# Altium schematic sheet USB_Controller.SchDoc(U_USB_Controller)
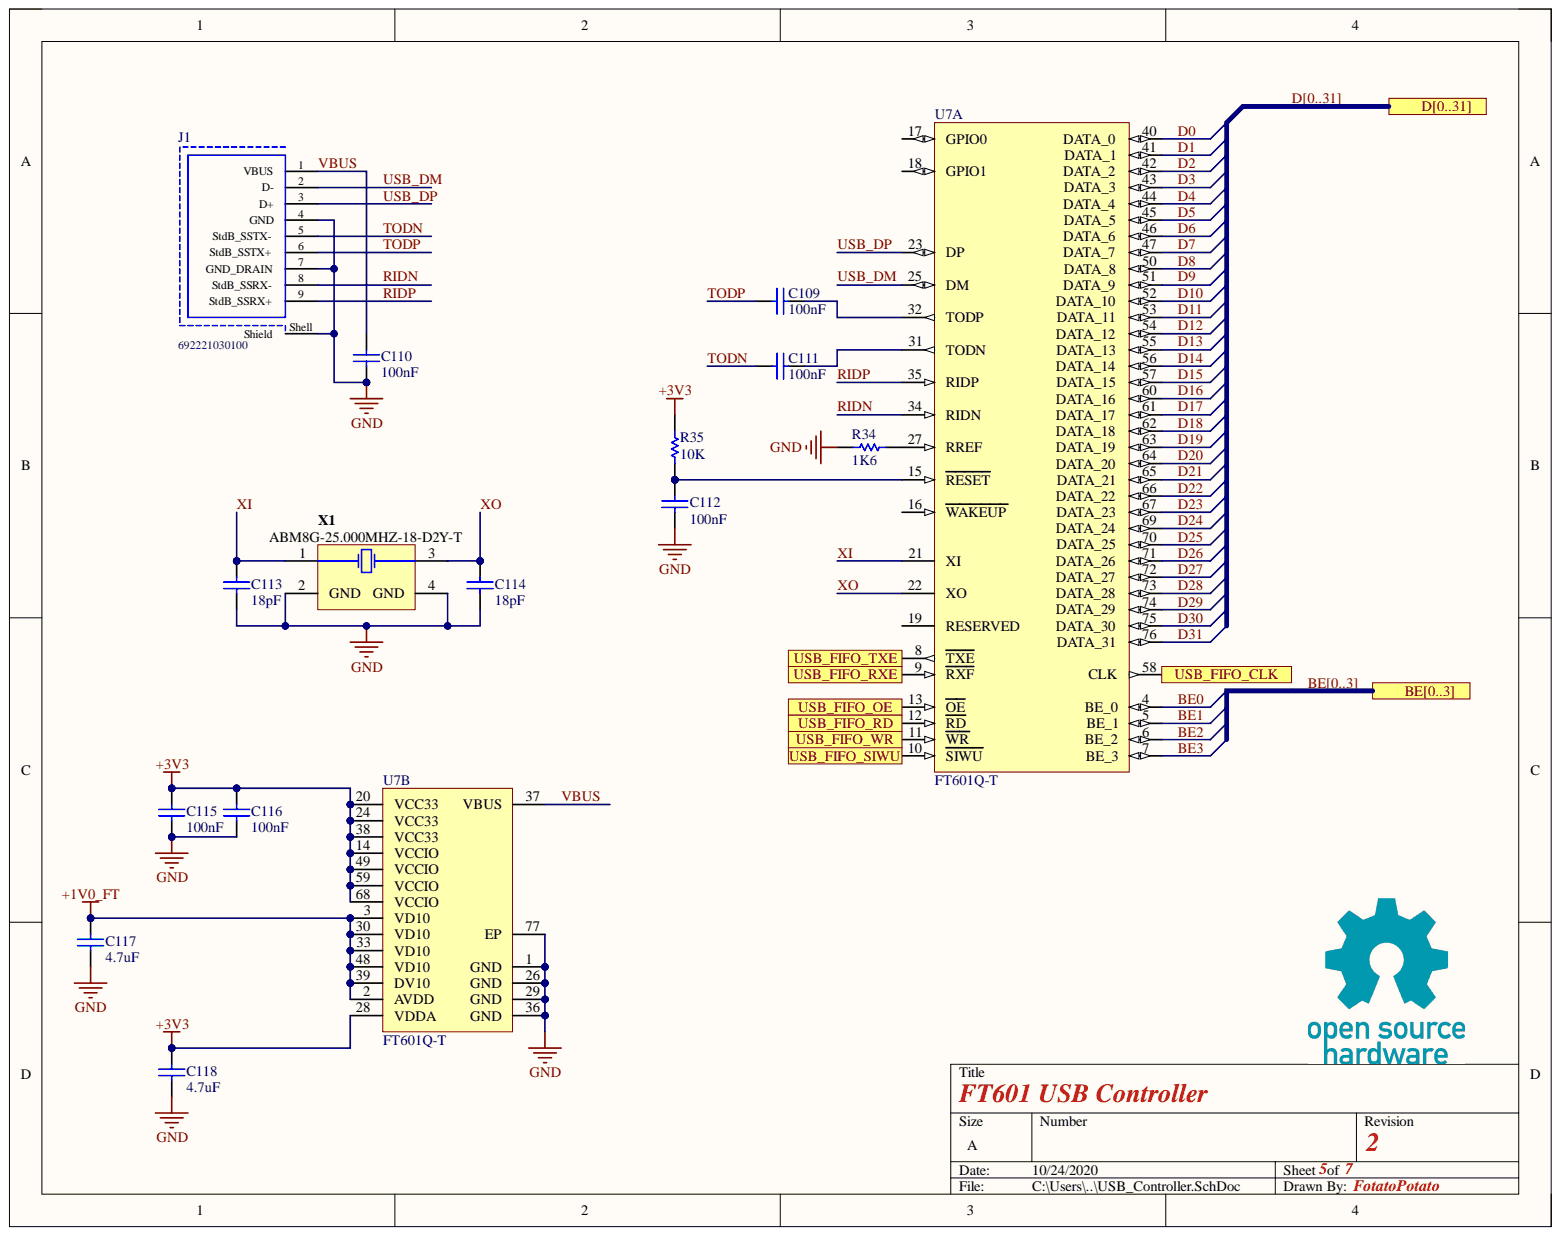
[diagram p0: USB_Controller.SchDoc]

## Parts
C109  100nF  [CAPC1005X56X25LL10T15]
C110  100nF  [CAPC1005X56X25LL10T15]
C111  100nF  [CAPC1005X56X25LL10T15]
C112  100nF  [CAPC1005X56X25LL10T15]
C113  18pF  [CAPC1005X56X25ML10T15]
C114  18pF  [CAPC1005X56X25ML10T15]
C115  100nF  [CAPC1005X56X25LL10T15]
C116  100nF  [CAPC1005X56X25LL10T15]
C117  4.7uF  [CAPC1005X55X25NL05T10]
C118  4.7uF  [CAPC1005X55X25NL05T10]
J1  692221030100  [692221030100]
R34  1K6  [RESC1005X40X25LL10T10]
R35  10K  [RESC1005X40X25NL10T10]
U7  FT601Q-T  [FTDI-QFN-76_M]  (parts A,B)
X1  ABM8G-25.000MHZ-18-D2Y-T  Load Capacitance: 18pF  [ABRA-ABM8G_V]

## Ports
BE0, BE1, BE2, BE3, BE[0..3], D0, D1, D2, D3, D4, D5, D6, D7, D8, D9, D10, D11, D12, D13, D14, D15, D16, D17, D18, D19, D20, D21, D22, D23, D24, D25, D26, D27, D28, D29, D30, D31, D[0..31], USB_FIFO_CLK, USB_FIFO_OE, USB_FIFO_RD, USB_FIFO_RXE, USB_FIFO_SIWU, USB_FIFO_TXE, USB_FIFO_WR

## Nets
+1V0_FT: C117.2 U7.2(AVDD) U7.3(VD10) U7.30(VD10) U7.33(VD10) U7.39(DV10) U7.48(VD10)
+3V3: C115.2 C116.2 C118.2 R35.2 U7.14(VCCIO) U7.20(VCC33) U7.24(VCC33) U7.28(VDDA) U7.38(VCC33) U7.49(VCCIO) U7.59(VCCIO) U7.68(VCCIO)
GND: C110.1 C112.2 C113.1 C114.1 C115.1 C116.1 C117.1 C118.1 J1.4(GND) J1.7(GND_DRAIN) J1.Shell(Shield) R34.1 U7.1(GND) U7.26(GND) U7.29(GND) U7.36(GND) U7.77(EP) X1.2(GND) X1.4(GND)
BE0: U7.4(BE_0) ; port BE[0..3]  [also labelled BE]
BE1: U7.5(BE_1) ; port BE[0..3]  [also labelled BE]
BE2: U7.6(BE_2) ; port BE[0..3]  [also labelled BE]
BE3: U7.7(BE_3) ; port BE[0..3]  [also labelled BE]
D0: U7.40(DATA_0) ; port D[0..31]  [also labelled D]
D1: U7.41(DATA_1) ; port D[0..31]  [also labelled D]
D2: U7.42(DATA_2) ; port D[0..31]  [also labelled D]
D3: U7.43(DATA_3) ; port D[0..31]  [also labelled D]
D4: U7.44(DATA_4) ; port D[0..31]  [also labelled D]
D5: U7.45(DATA_5) ; port D[0..31]  [also labelled D]
D6: U7.46(DATA_6) ; port D[0..31]  [also labelled D]
D7: U7.47(DATA_7) ; port D[0..31]  [also labelled D]
D8: U7.50(DATA_8) ; port D[0..31]  [also labelled D]
D9: U7.51(DATA_9) ; port D[0..31]  [also labelled D]
D10: U7.52(DATA_10) ; port D[0..31]  [also labelled D]
D11: U7.53(DATA_11) ; port D[0..31]  [also labelled D]
D12: U7.54(DATA_12) ; port D[0..31]  [also labelled D]
D13: U7.55(DATA_13) ; port D[0..31]  [also labelled D]
D14: U7.56(DATA_14) ; port D[0..31]  [also labelled D]
D15: U7.57(DATA_15) ; port D[0..31]  [also labelled D]
D16: U7.60(DATA_16) ; port D[0..31]  [also labelled D]
D17: U7.61(DATA_17) ; port D[0..31]  [also labelled D]
D18: U7.62(DATA_18) ; port D[0..31]  [also labelled D]
D19: U7.63(DATA_19) ; port D[0..31]  [also labelled D]
D20: U7.64(DATA_20) ; port D[0..31]  [also labelled D]
D21: U7.65(DATA_21) ; port D[0..31]  [also labelled D]
D22: U7.66(DATA_22) ; port D[0..31]  [also labelled D]
D23: U7.67(DATA_23) ; port D[0..31]  [also labelled D]
D24: U7.69(DATA_24) ; port D[0..31]  [also labelled D]
D25: U7.70(DATA_25) ; port D[0..31]  [also labelled D]
D26: U7.71(DATA_26) ; port D[0..31]  [also labelled D]
D27: U7.72(DATA_27) ; port D[0..31]  [also labelled D]
D28: U7.73(DATA_28) ; port D[0..31]  [also labelled D]
D29: U7.74(DATA_29) ; port D[0..31]  [also labelled D]
D30: U7.75(DATA_30) ; port D[0..31]  [also labelled D]
D31: U7.76(DATA_31) ; port D[0..31]  [also labelled D]
RIDN: J1.8(StdB_SSRX-) U7.34(RIDN)
RIDP: J1.9(StdB_SSRX+) U7.35(RIDP)
TODN: C111.2 J1.5(StdB_SSTX-)
TODP: C109.2 J1.6(StdB_SSTX+)
USB_DM: J1.2(D-) U7.25(DM)
USB_DP: J1.3(D+) U7.23(DP)
USB_FIFO_CLK: U7.58(CLK) ; port USB_FIFO_CLK
USB_FIFO_OE: U7.13(OE) ; port USB_FIFO_OE
USB_FIFO_RD: U7.12(RD) ; port USB_FIFO_RD
USB_FIFO_RXE: U7.9(RXF) ; port USB_FIFO_RXE
USB_FIFO_SIWU: U7.10(SIWU) ; port USB_FIFO_SIWU
USB_FIFO_TXE: U7.8(TXE) ; port USB_FIFO_TXE
USB_FIFO_WR: U7.11(WR) ; port USB_FIFO_WR
VBUS: C110.2 J1.1(VBUS) U7.37(VBUS)
XI: C113.2 U7.21(XI) X1.1
XO: C114.2 U7.22(XO) X1.3
NetC109_1: C109.1 U7.32(TODP)
NetC111_1: C111.1 U7.31(TODN)
NetC112_1: C112.1 R35.1 U7.15(RESET)
NetR34_2: R34.2 U7.27(RREF)

Not connected: U7 16(WAKEUP) 17(GPIO0) 18(GPIO1) 19(RESERVED)

## Library data of the parts
C109-C112 C115 C116 = CMP-2008-02743-1: Manufacturer: AVX Interconnect / Elco; Part Number: 04026D104KAT2A; Component Kind: Standard; Library Name: Altium Content Vault
C113 C114 = CMP-2008-02592-1: Manufacturer: AVX Interconnect / Elco; Part Number: 04025A180JAT2A; Component Kind: Standard; Library Name: Altium Content Vault
C117 C118 = CMP-2008-04549-1: Manufacturer: Murata; Part Number: GRM155R60J475ME47D; Component Kind: Standard; Library Name: Altium Content Vault
J1 = CMP-1502-03224-1: USB 3.0 Type B Receptacle WR-COM, Horizontal, THT; Manufacturer: Wurth Elektronik; Contact Resistance: 50mOhm; Rated Current: 0.25A; Working Voltage: 30VAC; Component Kind: Standard; Connector Type: USB3.0TypeB; Datasheet URL: https://katalog.we-online.de/em/datasheet/692221030100.pdf; Gender: Receptacle; Library Name: Altium Content Vault; Manufacturer URL: http://www.we-online.de; Mounting Technology: THT; Operating Temperature: -20to85degC; Orientation: Horizontal; Package Reference: 692221030100; Packaging: Tray; Part Number: 692221030100; Publisher: WurthElektronik
R34 = CMP-2002-06005-1: Manufacturer: Multicomp; Part Number: MC00625W040211K6; Component Kind: Standard; Library Name: Altium Content Vault
R35 = CMP-2002-05875-1: Manufacturer: Panasonic; Part Number: ERA2AEB103X; Component Kind: Standard; Library Name: Altium Content Vault
U7 = CMP-1736-00001-1: 32 Bit USB 3.0 to FIFO Bridge, 5 Gbps, 3 to 3.6 V, -40 to 85 degC, 76-Pin QFN, Pb-Free, Tray; Case/Package: QFN; Component Kind: Standard; ComponentLink1Description: Manufacturer URL; ComponentLink1URL: http://www.ftdichip.com/; ComponentLink2Description: Datasheet; ComponentLink2URL: http://www.ftdichip.com/Support/Documents/DataSheets/ICs/DS_FT600Q-FT601Q%20IC%20Datasheet.pdf; DatasheetVersion: Rev. 1.02, 02/2016; Library Name: Altium Content Vault; Mounting Technology: Surface Mount; PackageDescription: 76-Pin Quad Flat No-lead Package, Body 9 x 9 mm, Pitch 0.4 mm, Thermal Pad 5.45 x 5.45 mm; PartNumber: FT601Q-T; RoHSCompliant: false
X1 = CMP-2000-05733-1: Ultra Miniature Ceramic Glass Sealed SMD Crystal, 25 MHz, -40 to 85 degC, 4-Pin SMD, RoHS, Tape and Reel; Brand: ABRACON; Case Height: 1mm; Case Length: 3.2mm; Case Width: 2.5mm; ESR: 60Ohms; Frequency: 25MHz; Frequency Stability: 30PPM; Tolerance: 20PPM; Component Kind: Standard; Datasheet URL: http://www.abracon.com/Resonators/ABM8G.pdf; Datasheet Version: 08/2015; Dimensions: 2.5mmWx3.2mmLx1mmH; Factory Pack Quantity: 1000; Library Name: Altium Content Vault; Manufacturer: ABRACON; Manufacturer URL: http://www.abracon.com/; Mounting Style: SMD/SMT; Mounting Technology: SurfaceMount; Operating Temperature Range: -40Cto+85C; Package / Case: 3.2mmx2.5mm; Package Description: 4-Pin Surface Mount Device, Body 2.5 x 3.2 mm, Pitch 2.2 mm; Package Reference: ABM8G; Packaging: Reel; Product Category: Crystals; RoHS: Details; Series: ABM8G; Termination Style: SMD/SMT; Type: UltraMiniatureGlassSealedCrystal
